# Altium schematic sheet 5.04.51805A02_ STMX1.SchDoc("5.04.51805A02_ STMX1")
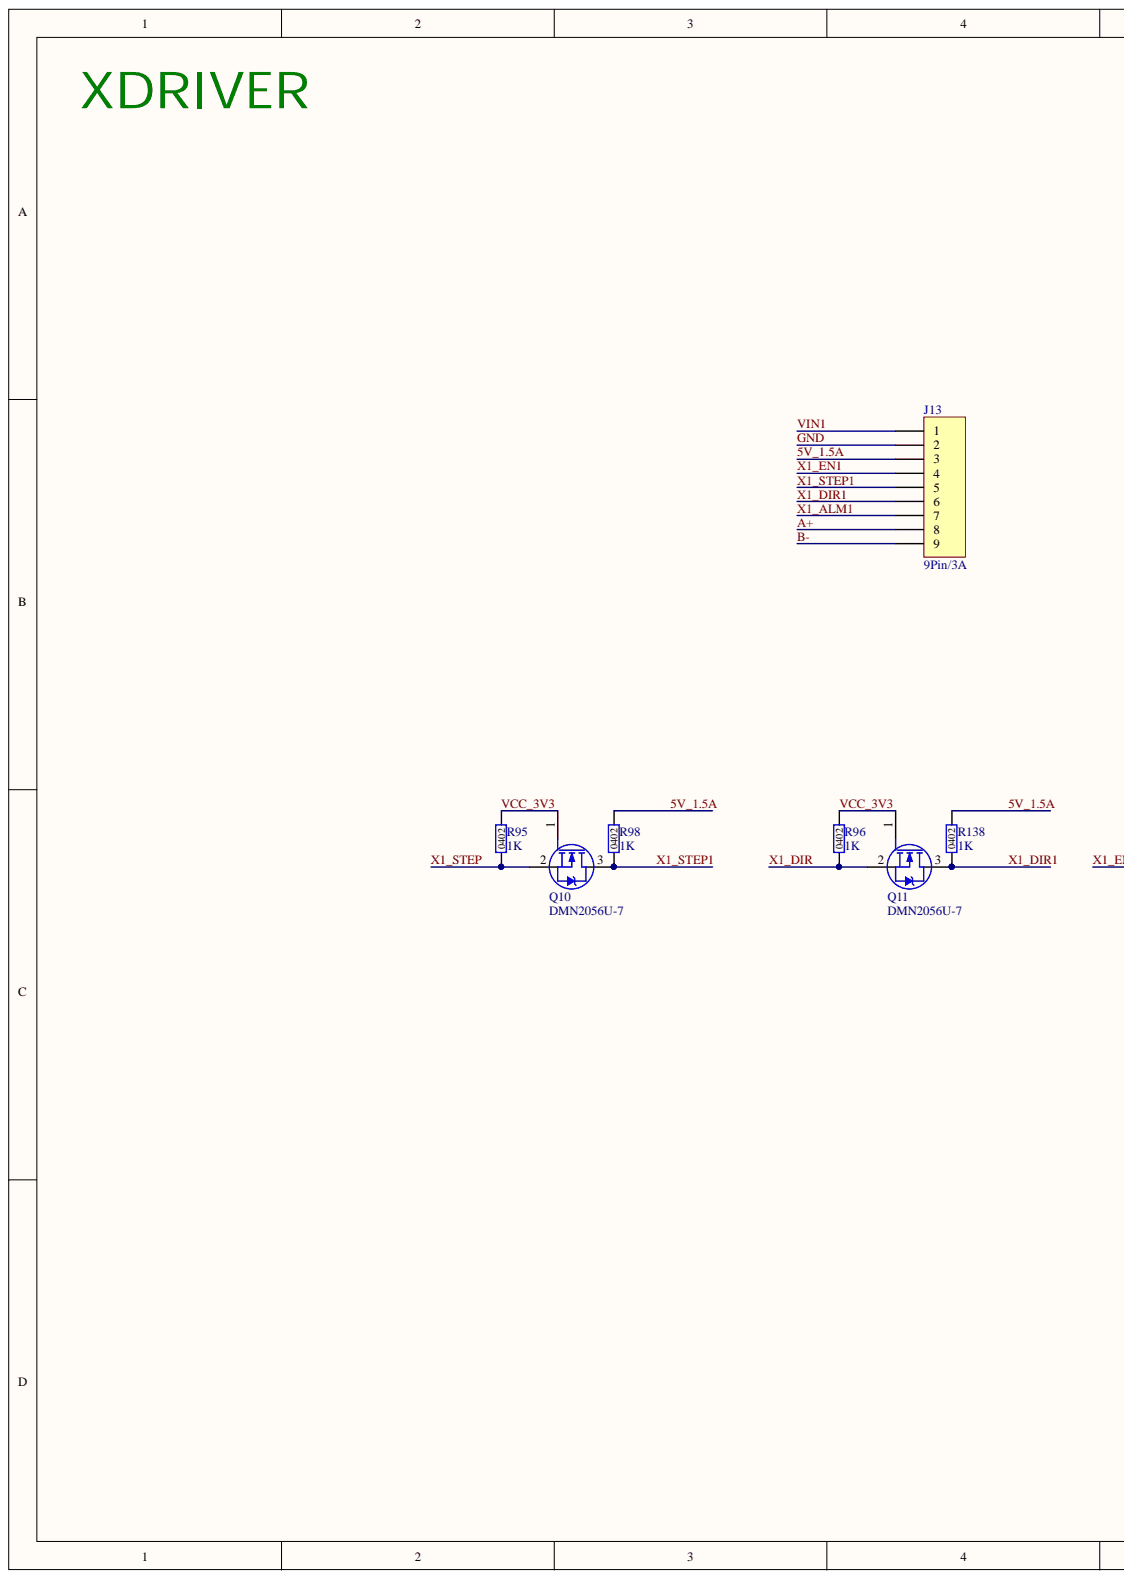
[diagram p0: 5.04.51805A02_ STMX1.SchDoc, left]
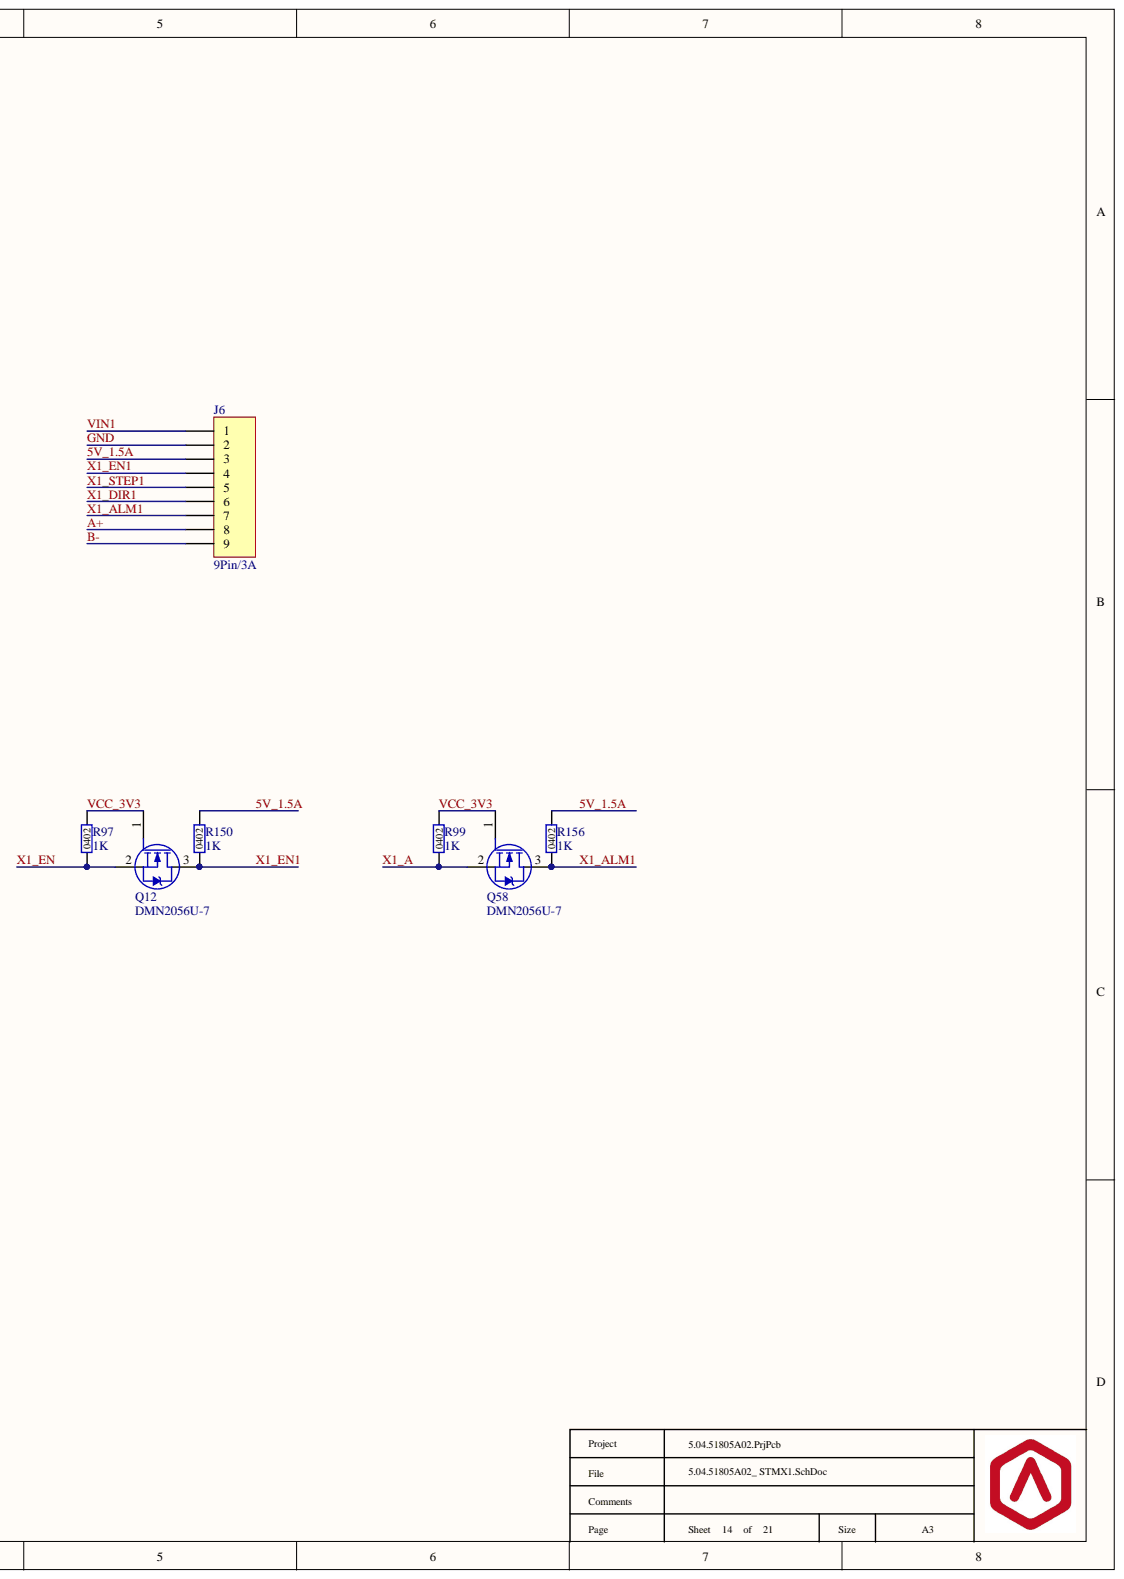
[diagram p1: 5.04.51805A02_ STMX1.SchDoc, right]

## Parts
J6  9Pin/3A  [CONN-TH_B9B-XH-A-LF-SN]
J13  9Pin/3A  [CONN-TH_B9B-XH-A-LF-SN]
Q10  DMN2056U-7  [SOT-23-3]
Q11  DMN2056U-7  [SOT-23-3]
Q12  DMN2056U-7  [SOT-23-3]
Q58  DMN2056U-7  [SOT-23-3]
R95  1K  [R0402]
R96  1K  [R0402]
R97  1K  [R0402]
R98  1K  [R0402]
R99  1K  [R0402]
R138  1K  [R0402]
R150  1K  [R0402]
R156  1K  [R0402]

## Nets
5V_1.5A: J6.3 J13.3 R98.2 R138.2 R150.2 R156.2
A+: J6.8 J13.8
B-: J6.9 J13.9
GND: J6.2 J13.2
VCC_3V3: Q10.1 Q11.1 Q12.1 Q58.1 R95.2 R96.2 R97.2 R99.2
VIN1: J6.1 J13.1
X1_A: Q58.2 R99.1
X1_ALM1: J6.7 J13.7 Q58.3 R156.1
X1_DIR: Q11.2 R96.1
X1_DIR1: J6.6 J13.6 Q11.3 R138.1
X1_EN: Q12.2 R97.1
X1_EN1: J6.4 J13.4 Q12.3 R150.1
X1_STEP: Q10.2 R95.1
X1_STEP1: J6.5 J13.5 Q10.3 R98.1

## Library data of the parts
J6 J13 = JW_B9B-XH-A(LF)(SN): 线对板连接器，9PINS,PITCH2.5mm.TH,OR,,3A,白，-25~+85℃; Manufacturer1: JST; Code: 550100XX; Component Kind: Standard; ManufacturerPartNumber1: B9B-XH-A(LF)(SN); Library Name: Raise3D_Connector.IntLib
Q10-Q12 Q58 = NMOS_DMN2056U-7: N-MOSFET,DMN2056U-7,1Channel,Vds=20V,Id=4A,Vgs=+/-8V,TO-236-3,-55°C~150°C; Manufacturer1: DIODES; Code: 54010011; Component Kind: Standard; ManufacturerPartNumber1: DMN2056U-7; Library Name: Raise3D_MOS&BJT.IntLib
R95-R99 R138 R150 R156 = R0402_1K: Resistor 1Kohm,5%,1/16W,R0402; Manufacturer1: Yageo; ManufacturerPartNumber1: RC0402JR-071KL; Code: 5011001K; Component Kind: Standard
  R95-R98 R138 R150 R156: Library Name: Raise3D_Resistor.SCHLIB
  R99: Library Name: Raise3D_Resistor.IntLib
